# Altium schematic sheet TOP.SchDoc
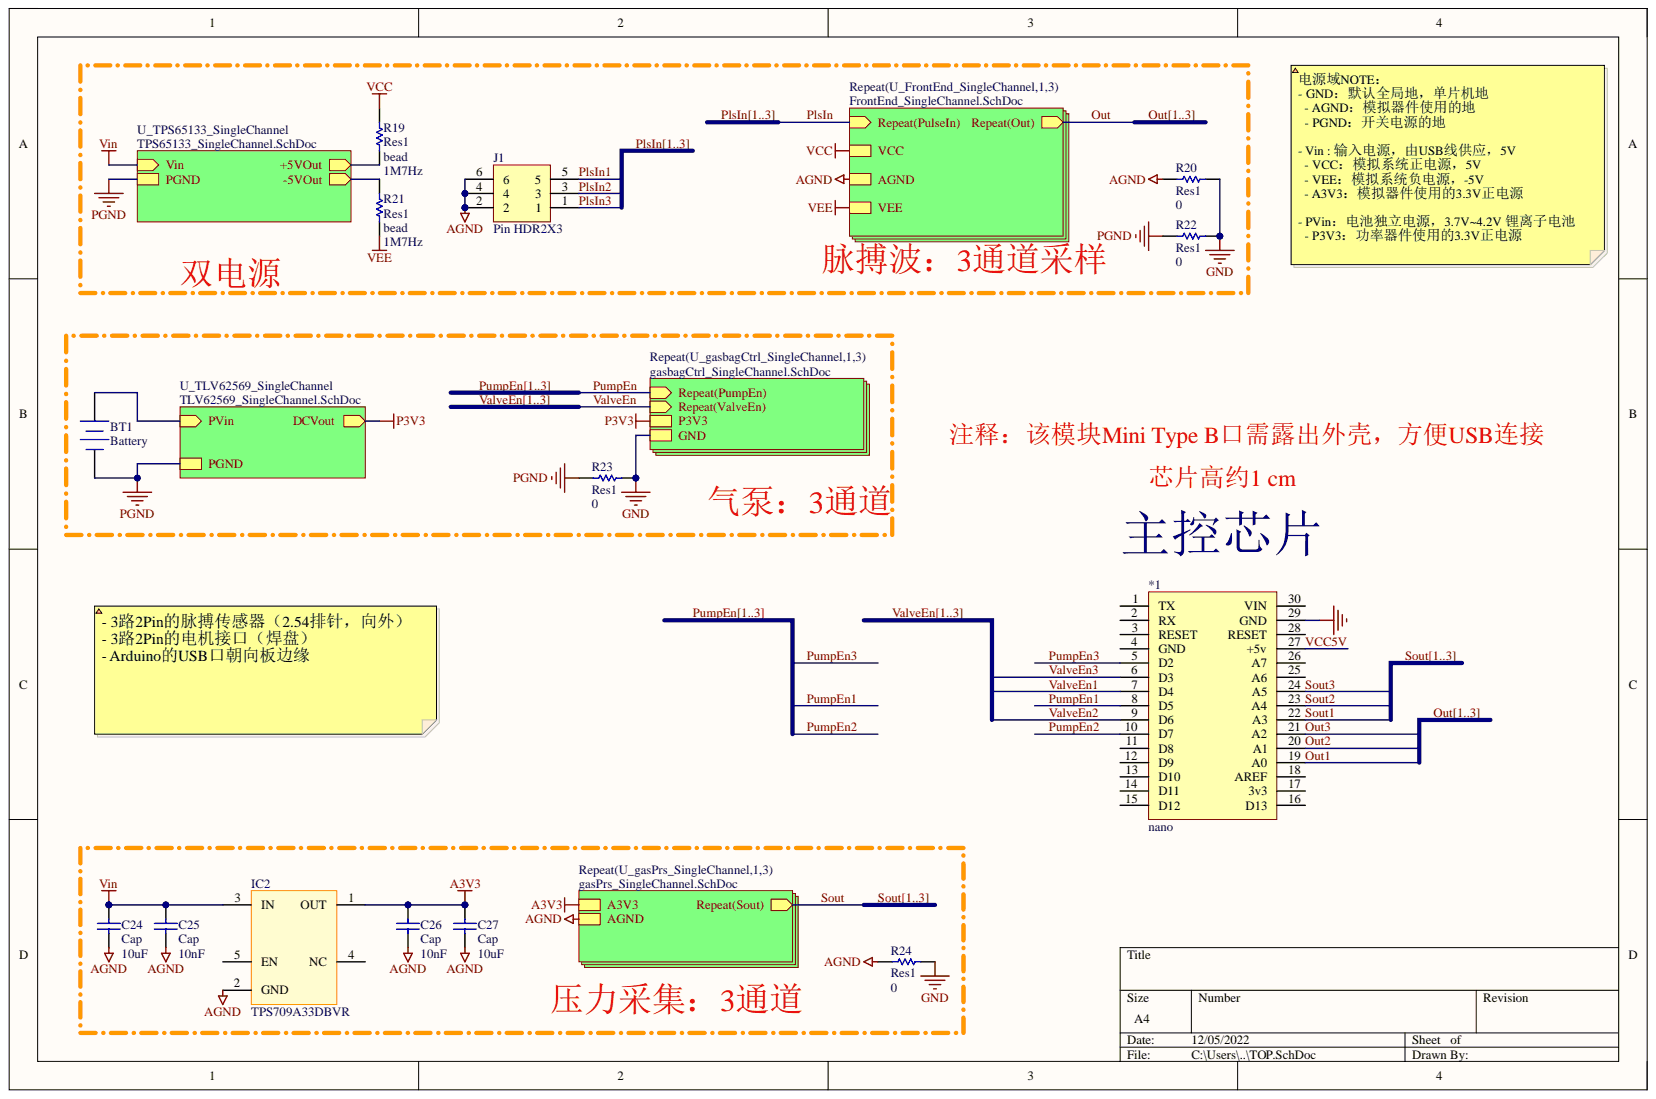
[diagram p0: TOP.SchDoc]

## Parts
*1  nano  [nano]
BT1  Battery  [BAT-2]
C24  Cap  value 10uF  [0603C]
C25  Cap  value 10nF  [0603C]
C26  Cap  value 10nF  [0603C]
C27  Cap  value 10uF  [0603C]
IC2  TPS709A33DBVR  [SOT95P280X145-5N]
J1  Pin HDR2X3  [Pin HDR2X3/2.54mm-S]
R19  Res1  value bead  Frequency: 1M7Hz  [0603R]
R20  Res1  [0603R]
R21  Res1  value bead  Frequency: 1M7Hz  [0603R]
R22  Res1  value 0  [0603R]
R23  Res1  value 0  [0603R]
R24  Res1  value 0  [0603R]

## Nets
A3V3: C26.2 C27.2 IC2.1(OUT)
AGND: C24.1 C25.1 C26.1 C27.1 IC2.2(GND) J1.2 J1.4 J1.6 R20.1 R24.1
GND: *1.29(GND) R20.2 R22.2 R23.2 R24.2
PGND: BT1.2 R22.1 R23.1
VCC: R19.2
VEE: R21.1
Vin: C24.2 C25.2 IC2.3(IN)
Out1: *1.19(A0)  [also labelled Out]
Out2: *1.20(A1)  [also labelled Out]
Out3: *1.21(A2)  [also labelled Out]
PlsIn1: J1.5  [also labelled PlsIn]
PlsIn2: J1.3  [also labelled PlsIn]
PlsIn3: J1.1  [also labelled PlsIn]
PumpEn1: *1.8(D5)  [also labelled PumpEn]
PumpEn2: *1.10(D7)  [also labelled PumpEn]
PumpEn3: *1.5(D2)  [also labelled PumpEn]
Sout1: *1.22(A3)  [also labelled Sout]
Sout2: *1.23(A4)  [also labelled Sout]
Sout3: *1.24(A5)  [also labelled Sout]
ValveEn1: *1.7(D4)  [also labelled ValveEn]
ValveEn2: *1.9(D6)  [also labelled ValveEn]
ValveEn3: *1.6(D3)  [also labelled ValveEn]
VCC5V: *1.27(+5v)
Net*1_1: *1.1(TX)
Net*1_2: *1.2(RX)
Net*1_3: *1.3(RESET)
Net*1_4: *1.4(GND)
Net*1_11: *1.11(D8)
Net*1_12: *1.12(D9)
Net*1_13: *1.13(D10)
Net*1_14: *1.14(D11)
Net*1_15: *1.15(D12)
Net*1_16: *1.16(D13)
Net*1_17: *1.17(3v3)
Net*1_18: *1.18(AREF)
Net*1_25: *1.25(A6)
Net*1_26: *1.26(A7)
Net*1_28: *1.28(RESET)
Net*1_30: *1.30(VIN)
NetBT1_1: BT1.1
NetIC2_4: IC2.4(NC)
NetIC2_5: IC2.5(EN)
NetR19_1: R19.1
NetR21_2: R21.2
P3V3:

## Library data of the parts
BT1 = Battery: Multicell Battery; Component Kind: Standard; LatestRevisionDate: 17-Jul-2002; LatestRevisionNote: Re-released for DXP Platform.; Library Name: Miscellaneous Devices.IntLib; PackageDescription: Battery; 2 Leads; PackageReference: BAT-2; Published: 24-Mar-1999; Publisher: Altium Limited
C24-C27 = Cap: Capacitor; Component Kind: Standard; LatestRevisionDate: 17-Jul-2002; LatestRevisionNote: Re-released for DXP Platform.; Library Name: Miscellaneous Devices.IntLib; PackageDescription: Radial Cap, Thru-Hole; 2 Leads; 0.3 in Pin Spacing; PackageReference: RAD-0.3; Published: 8-Jun-2000; Signal Integrity: Cap; Simulation: CAP; Publisher: Altium Limited
IC2 = TPS709A33DBVR: 3.3V 高CMRR LDO; Manufacturer_Name: Texas Instruments; Arrow Part Number: TPS709A33DBVR; Arrow Price/Stock: https://www.arrow.com/en/products/tps709a33dbvr/texas-instruments?region=nac; Component Kind: Standard; Datasheet Link: http://www.ti.com/lit/gpn/tps709; Height: 1.45mm; Manufacturer_Part_Number: TPS709A33DBVR; Mouser Part Number: 595-TPS709A33DBVR; Mouser Price/Stock: https://www.mouser.co.uk/ProductDetail/Texas-Instruments/TPS709A33DBVR?qs=8%2FmU9qzJpL8xC3krkiti2Q%3D%3D; Library Name: 脉诊.SchLib
R19 R21-R24 = Res1: Resistor; Component Kind: Standard; LatestRevisionDate: 17-Jul-2002; LatestRevisionNote: Re-released for DXP Platform.; Library Name: Miscellaneous Devices.IntLib; PackageDescription: Axial Device, Thru-Hole; 2 Leads; 0.3 in Pin Spacing; PackageReference: AXIAL-0.3; Published: 8-Jun-2000; Signal Integrity: Res; Simulation: RESISTOR; Publisher: Altium Limited
R20 = Res1: Resistor; Value: 0; Component Kind: Standard; LatestRevisionDate: 17-Jul-2002; LatestRevisionNote: Re-released for DXP Platform.; Library Name: Miscellaneous Devices.IntLib; PackageDescription: Axial Device, Thru-Hole; 2 Leads; 0.3 in Pin Spacing; PackageReference: AXIAL-0.3; Published: 8-Jun-2000; Signal Integrity: Res; Simulation: RESISTOR; Publisher: Altium Limited
*1 = nano: Component Kind: Standard; Library Name: 脉诊.SchLib
J1 = Pin HDR2X3: Component Kind: Standard; Library Name: 排针.IntLib
